# Altium schematic sheet DDS.SchDoc
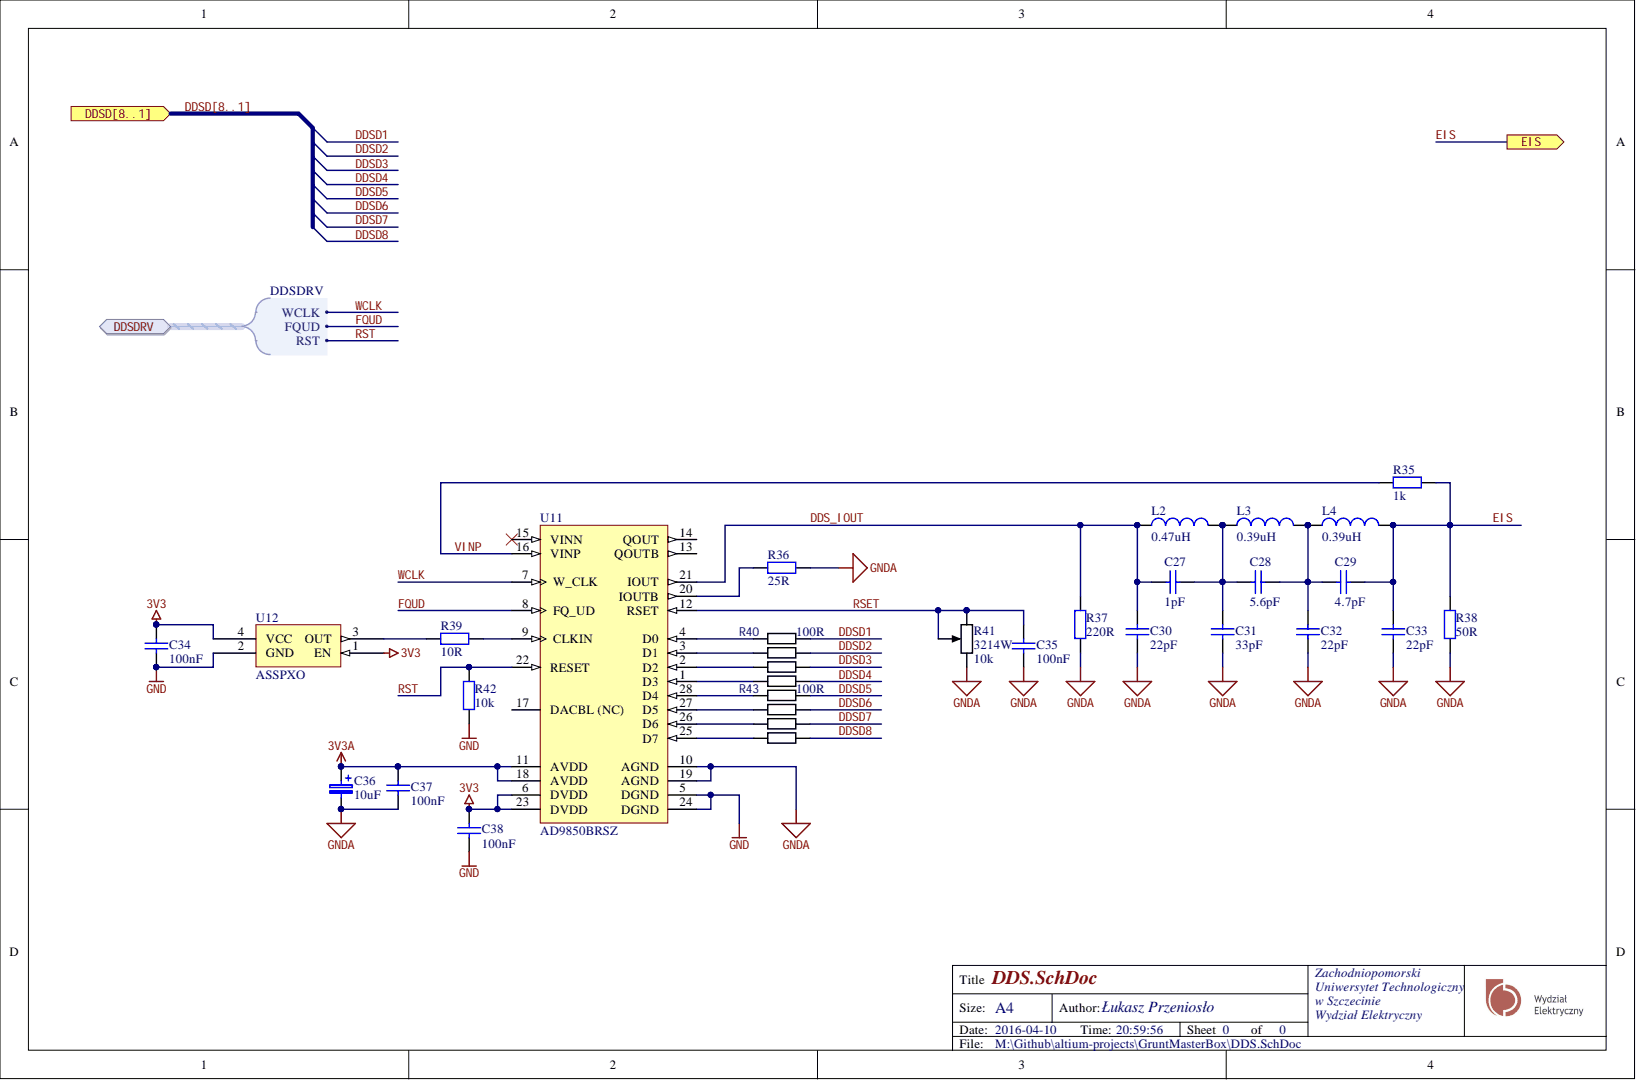
[diagram p0: DDS.SchDoc]

## Parts
C27  1pF  [CAPC1608N]
C28  5.6pF  [CAPC1608N]
C29  4.7pF  [CAPC1608N]
C30  22pF  [CAPC1608N]
C31  33pF  [CAPC1608N]
C32  22pF  [CAPC1608N]
C33  22pF  [CAPC1608N]
C34  100nF  [CAPC1608N]
C35  100nF  [CAPC1608N]
C36  10uF  [SMDA]
C37  100nF  [CAPC1608N]
C38  100nF  [CAPC1608N]
L2  value 0.47uH  [inductor_0603]  (hidden comment: Ferrite)
L3  value 0.39uH  [inductor_0603]  (hidden comment: Ferrite)
L4  value 0.39uH  [inductor_0603]  (hidden comment: Ferrite)
R35  1k  [RESC1608N]
R36  25R  [RESC1608N]
R37  220R  [RESC1608N]
R38  50R  [RESC1608N]
R39  10R  [RESC1608N]
R40  value 100R  [RES_ARRAY_4x0603]  (hidden comment: RES_ARRAY_4)
R41  3214W  value 10k  [POT3214W]
R42  10k  [RESC1608N]
R43  value 100R  [RES_ARRAY_4x0603]  (hidden comment: RES_ARRAY_4)
U11  AD9850BRSZ  [SSOP28]
U12  ASSPXO  [EPON_XO]

## Ports
DDSD1, DDSD2, DDSD3, DDSD4, DDSD5, DDSD6, DDSD7, DDSD8, DDSD[8..1], DDSDRV, DDSDRV.FQUD, DDSDRV.RST, DDSDRV.WCLK, EIS

## Nets
3V3: C34.1 C38.1 U11.6(DVDD) U11.23(DVDD) U12.1(EN) U12.4(VCC)
3V3A: C36.1 C37.1 U11.11(AVDD) U11.18(AVDD)
GND: C34.2 C38.2 R42.2 U11.5(DGND) U11.24(DGND) U12.2(GND)
GNDA: C30.1 C31.1 C32.1 C33.1 C35.1 C36.2 C37.2 R36.1 R37.2 R38.2 R41.3 U11.10(AGND) U11.19(AGND)
DDS_IOUT: C27.1 C30.2 L2.1 R37.1 U11.21(IOUT)
DDSD1: R40.7 ; port DDSD[8..1]  [also labelled DDSD]
DDSD2: R40.5 ; port DDSD[8..1]  [also labelled DDSD]
DDSD3: R40.3 ; port DDSD[8..1]  [also labelled DDSD]
DDSD4: R40.1 ; port DDSD[8..1]  [also labelled DDSD]
DDSD5: R43.7 ; port DDSD[8..1]  [also labelled DDSD]
DDSD6: R43.5 ; port DDSD[8..1]  [also labelled DDSD]
DDSD7: R43.3 ; port DDSD[8..1]  [also labelled DDSD]
DDSD8: R43.1 ; port DDSD[8..1]  [also labelled DDSD]
EIS: C29.2 C33.2 L4.2 R35.2 R38.1 ; port EIS
FQUD: U11.8(FQ_UD) ; port DDSDRV
RSET: C35.2 R41.1 R41.2 U11.12(RSET)
RST: R42.1 U11.22(RESET) ; port DDSDRV
VINP: R35.1 U11.16(VINP)
WCLK: U11.7(W_CLK) ; port DDSDRV
NetC27_2: C27.2 C28.1 C31.2 L2.2 L3.1
NetC28_2: C28.2 C29.1 C32.2 L3.2 L4.1
NetR36_2: R36.2 U11.20(IOUTB)
NetR39_1: R39.1 U11.9(CLKIN)
NetR39_2: R39.2 U12.3(OUT)
NetR40_2: R40.2 U11.1(D3)
NetR40_4: R40.4 U11.2(D2)
NetR40_6: R40.6 U11.3(D1)
NetR40_8: R40.8 U11.4(D0)
NetR43_2: R43.2 U11.25(D7)
NetR43_4: R43.4 U11.26(D6)
NetR43_6: R43.6 U11.27(D5)
NetR43_8: R43.8 U11.28(D4)

Not connected: U11 13(QOUTB) 14(QOUT) 15(VINN) 17

## Library data of the parts
C27-C35 C37 C38 = Capacitor: Component Kind: Standard; Description: Capacitor; Library Name: Discrete.SchLib; Signal Integrity: Cap; Simulation: CAP
  C27: Value: 1pF
  C28: Value: 5.6pF
  C29: Value: 4.7pF
  C30 C32 C33: Value: 22pF
  C31: Value: 33pF
  C34 C35 C37 C38: Value: 100nF
C36 = 100uF 6.3V-SMDB: Polarized Capacitor (Surface Mount); Component Kind: Standard; Distributor: tme.pl; Distributor Order Code: TC 100/6.3-SMDB; farnell: 1135048; PackageReference: SMDB; Signal Integrity: Cap Pol; Simulation: CAP; tme.pl: TC 100/6.3-SMDB; Value: 10uF; Library Name: Mechatronic.SchLib
L2-L4 = Inductor: Code_IEC: 1005; Code_JEITA: 0402; Component Kind: Standard; Description: Inductor; LatestRevisionDate: 29-May-2009; LatestRevisionNote: IPC-7351 Footprint Added.; Library Name: Miscellaneous Devices.IntLib; PackageDescription: Chip Inductor; PackageReference: 0402-A; Published: 8-Jun-2000; Signal Integrity: Inductor; Simulation: INDUCTOR; Publisher: Altium Limited
R35-R38 R42 = Resistor: Power: 0W; Tolerance: 1%; Component Kind: Standard; Description: Resistor; Library Name: Discrete.SchLib; Signal Integrity: Res; Simulation: RESISTOR
  R35: Value: 1k
  R36: Value: 25R
  R37: Value: 220R
  R38: Value: 50R
  R42: Value: 10k
R39 = Resistor: Power: 0W; Tolerance: 5%; Component Kind: Standard; Description: Resistor; Library Name: Discrete.SchLib; Signal Integrity: Res; Simulation: RESISTOR; Value: 10R
R40 R43 = RES_ARRAY_1206: Component Kind: Standard; Library Name: my_various_devices.SchLib
R41 = RPot: Potentiometer; Set Position: 0.5; Sim Note: Enter the Resistance value and the set point into parameter fields.; Component Kind: Standard; LatestRevisionDate: 17-Jul-2002; LatestRevisionNote: Re-released for DXP Platform.; PackageDescription: Variable Resistor, Thru-Hole; 3 Leads; PackageReference: VR5; Published: 8-Jun-2000; Simulation: POT; Library Name: my_various_devices.SchLib; Publisher: Altium Limited
U11 = AD9850: CMOS, 125 MHz Complete DDS Synthesizer, 10-Bit, Parallel, Serial, RS-28, 47 Tube, Pb-Free; Compliance Range (V): 1.5V; FS Iout (mA nom): 20mA; I Supply total (max): 96mA; Master fclk: 125MHz; REFCLK Multiplier: No; Resolution (Bits): 10bit; Tuning Word Width (bits): 32bit; Code_JEDEC: MO-150-AH; Component Kind: Standard; ComponentLink1Description: Manufacturer URL; ComponentLink1URL: www.analog.com; ComponentLink2Description: Datasheet; ComponentLink2URL: http://www.analog.com/static/imported-files/data_sheets/AD9850.pdf; ComponentLink3Description: Package Specification; ComponentLink3URL: http://www.analog.com/static/imported-files/packages/32122249223724RS_28.pdf; DatasheetVersion: Rev. H; I/O Interface: Parallel, Serial; PackageDescription: 28-Lead Shrink Small Outline Package [SSOP]; PackageReference: RS-28; PackageVersion: revA, 1997; Library Name: my_various_devices.SchLib
U12 = CFPS-39: Component Kind: Standard; Signal Integrity: ECS-3M; Library Name: my_various_devices.SchLib
